# Altium schematic sheet DTU_RS485_CAN.SchDoc(DTU_RS485_CAN)
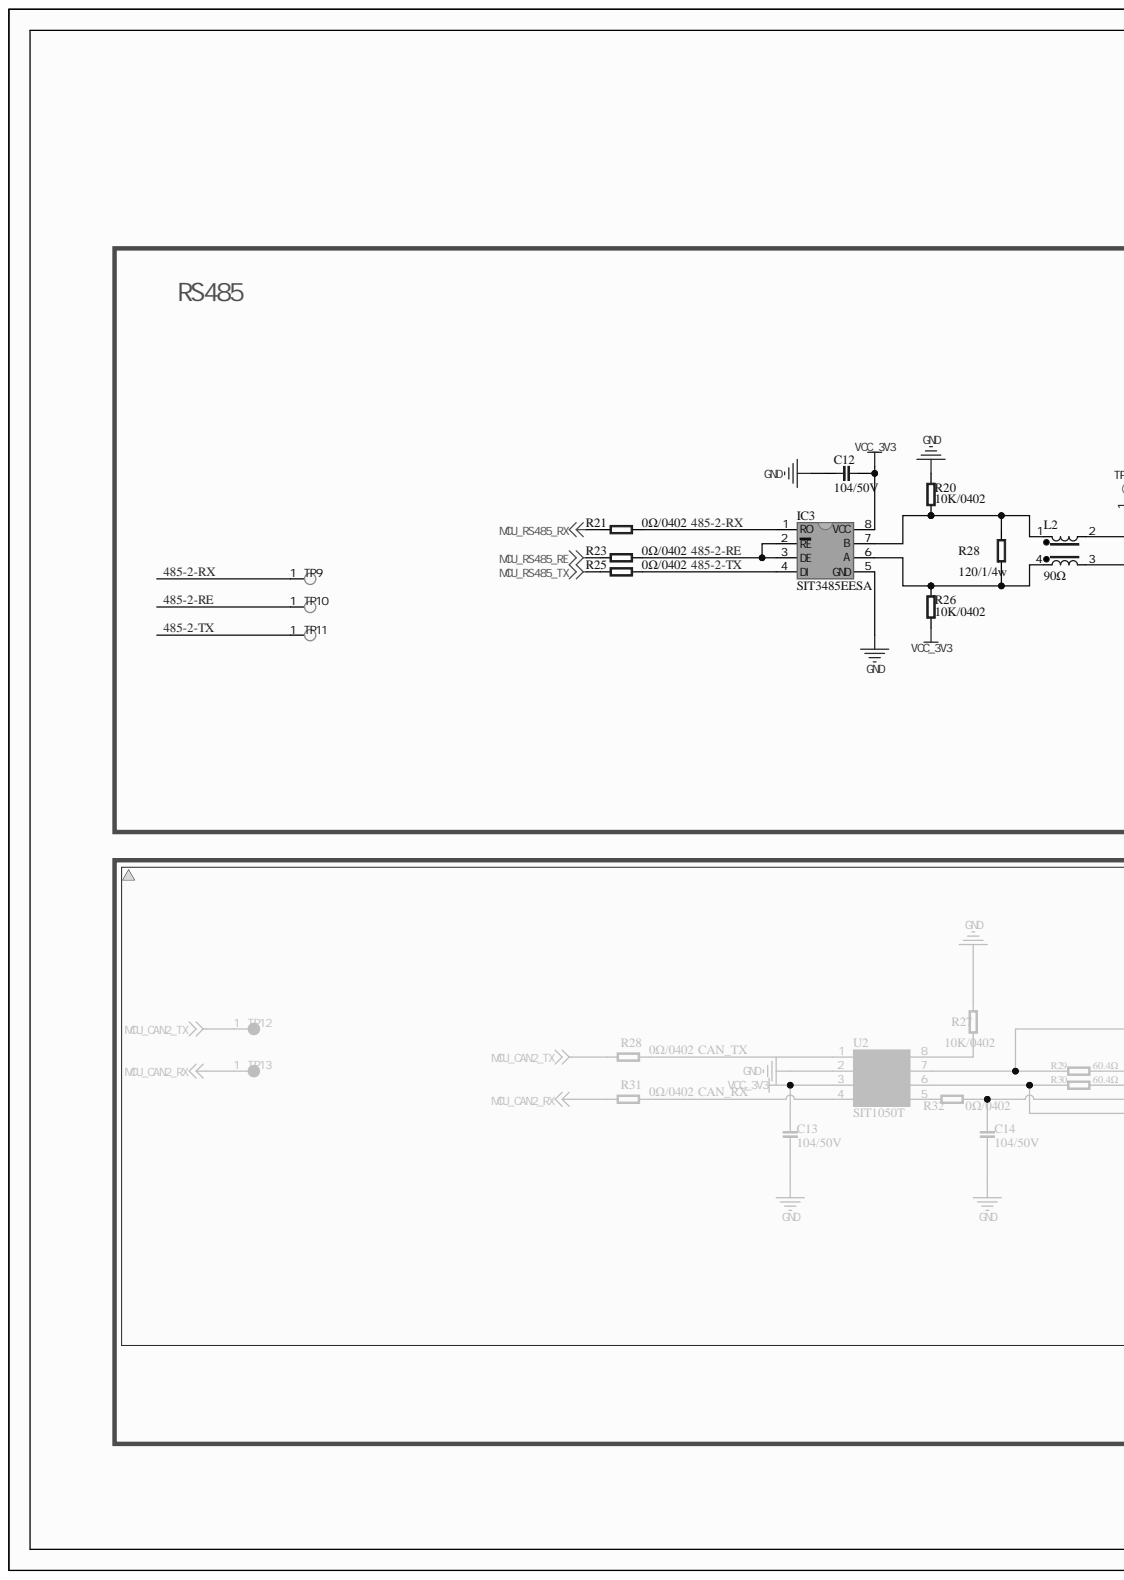
[diagram p0: DTU_RS485_CAN.SchDoc, left]
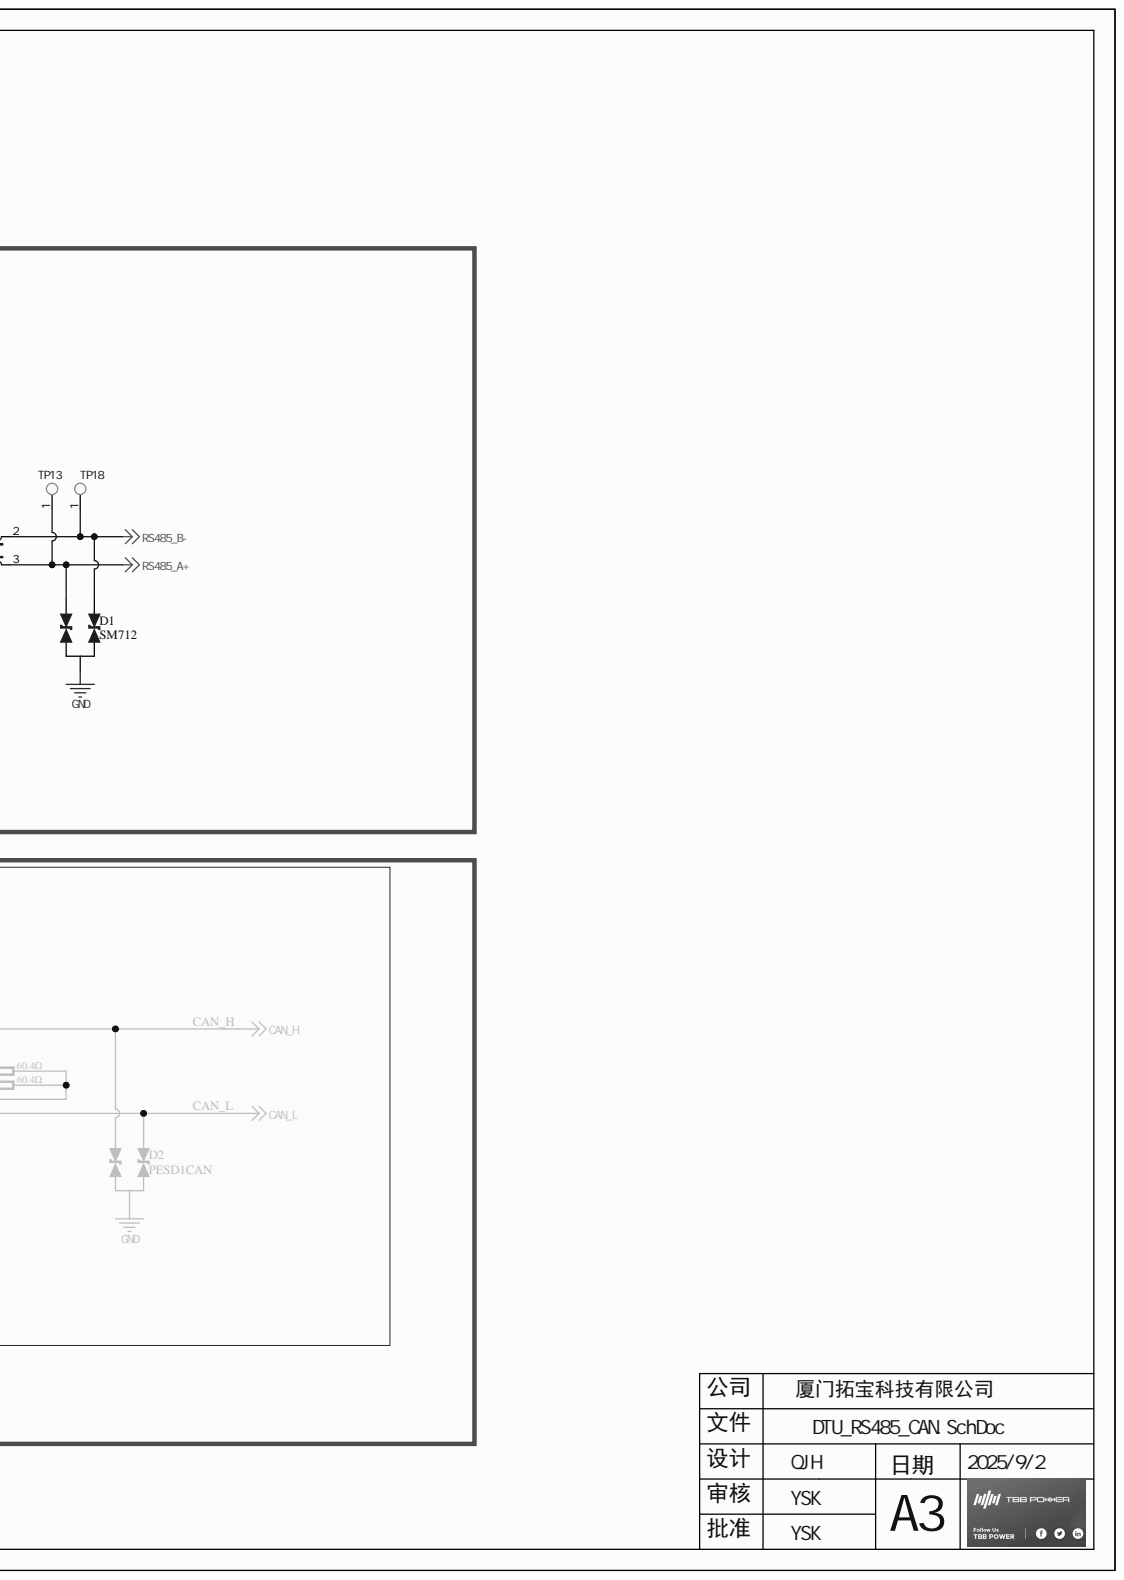
[diagram p1: DTU_RS485_CAN.SchDoc, right]

## Parts
C12  104/50V  [C0402]
D1  SM712  [SOT-23]
IC3  SIT3485EESA  [SO-8]
L2  90Ω  [SDCW3216]
R20  10K/0402  [R0402]
R21  0Ω/0402  [R0402]
R23  0Ω/0402  [R0402]
R25  0Ω/0402  [R0402]
R26  10K/0402  [R0402]
R28  120/1/4w  [R1206]
TP9  TP  [TP-SMD]  (value not drawn)  (no BOM)
TP10  TP  [TP-SMD]  (value not drawn)  (no BOM)
TP11  TP  [TP-SMD]  (value not drawn)  (no BOM)
TP13  TP  [TP-SMD]  (no BOM)
TP18  TP  [TP-SMD]  (no BOM)

## Nets
GND: C12.1 D1.3 IC3.5(GND) R20.2
MCU_RS485_RE: R23.1
MCU_RS485_RX: R21.1
MCU_RS485_TX: R25.1
RS485_A+: D1.2 L2.3 TP13.1
RS485_B-: D1.1 L2.2 TP18.1
VCC_3V3: C12.2 IC3.8(VCC) R26.1
485-2-RE: IC3.2(RE) IC3.3(DE) R23.2 TP10.1
485-2-RX: IC3.1(RO) R21.2 TP9.1
485-2-TX: IC3.4(DI) R25.2 TP11.1
NetIC3_6: IC3.6(A) L2.4 R26.2 R28.2
NetIC3_7: IC3.7(B) L2.1 R20.1 R28.1

## Library data of the parts
C12 = C0402_100nF_50V: 贴片电容,X7R,0402,104,100nF,50V,±10%,-55℃～125℃; Part_number: 10206-0123; Component Kind: Standard; Library Name: Capacity.SchLib
D1 = SM712: 贴片ESD瞬态抑制二极管,SM712,SOT-23,双向 Vrwm=7V(pin3to1/2); Part_number: 10501-0074; Component Kind: Standard; 料号: 10501-0074; Library Name: EMS-主板（PCB-211113-01）V01.SCHLIB
IC3 = SIT3485EESA: 贴片IC,SIT3485EESA,485通讯芯片,兼容5V和3.3V,SOP8,-40℃~125℃; Part_number: 10802-0210; Component Kind: Standard; Library Name: Q_IC.SchLib
L2 = SDCW3216-2-900TF: 贴片共模电感,SDCW3216-2-900TF,90Ω,±25%,0.35Ω; Part_number: 10303-0029; Component Kind: Standard; Library Name: INDUCTANCE.IntLib; 料号: 10303-0029
R20 R26 = R0402_10K: 贴片电阻,RTT02103JTH,0402,10K,5%,Ralec; Part_number: 10102-0279; Component Kind: Standard; 料号: 10102-0279; Library Name: Resistance.SchLib
R21 R23 R25 = R0402_0Ω: 贴片电阻,0402,0Ω,1/16W,±1%; Part_number: 10102-0253; Component Kind: Standard; Library Name: Resistance.SchLib
R28 = R1206: 贴片电阻,1206,120Ω,1/4W,±1%; Part_number: 10102-0321; Component Kind: Standard; Library Name: RESISTOR.IntLib; 料号: 10102-0321
TP9-TP11 TP13 TP18 = TP: PCB测试端子; Library Name: CONNECTOR.IntLib
